# Altium schematic sheet Microcontroller.SchDoc(U_Microcontroller)
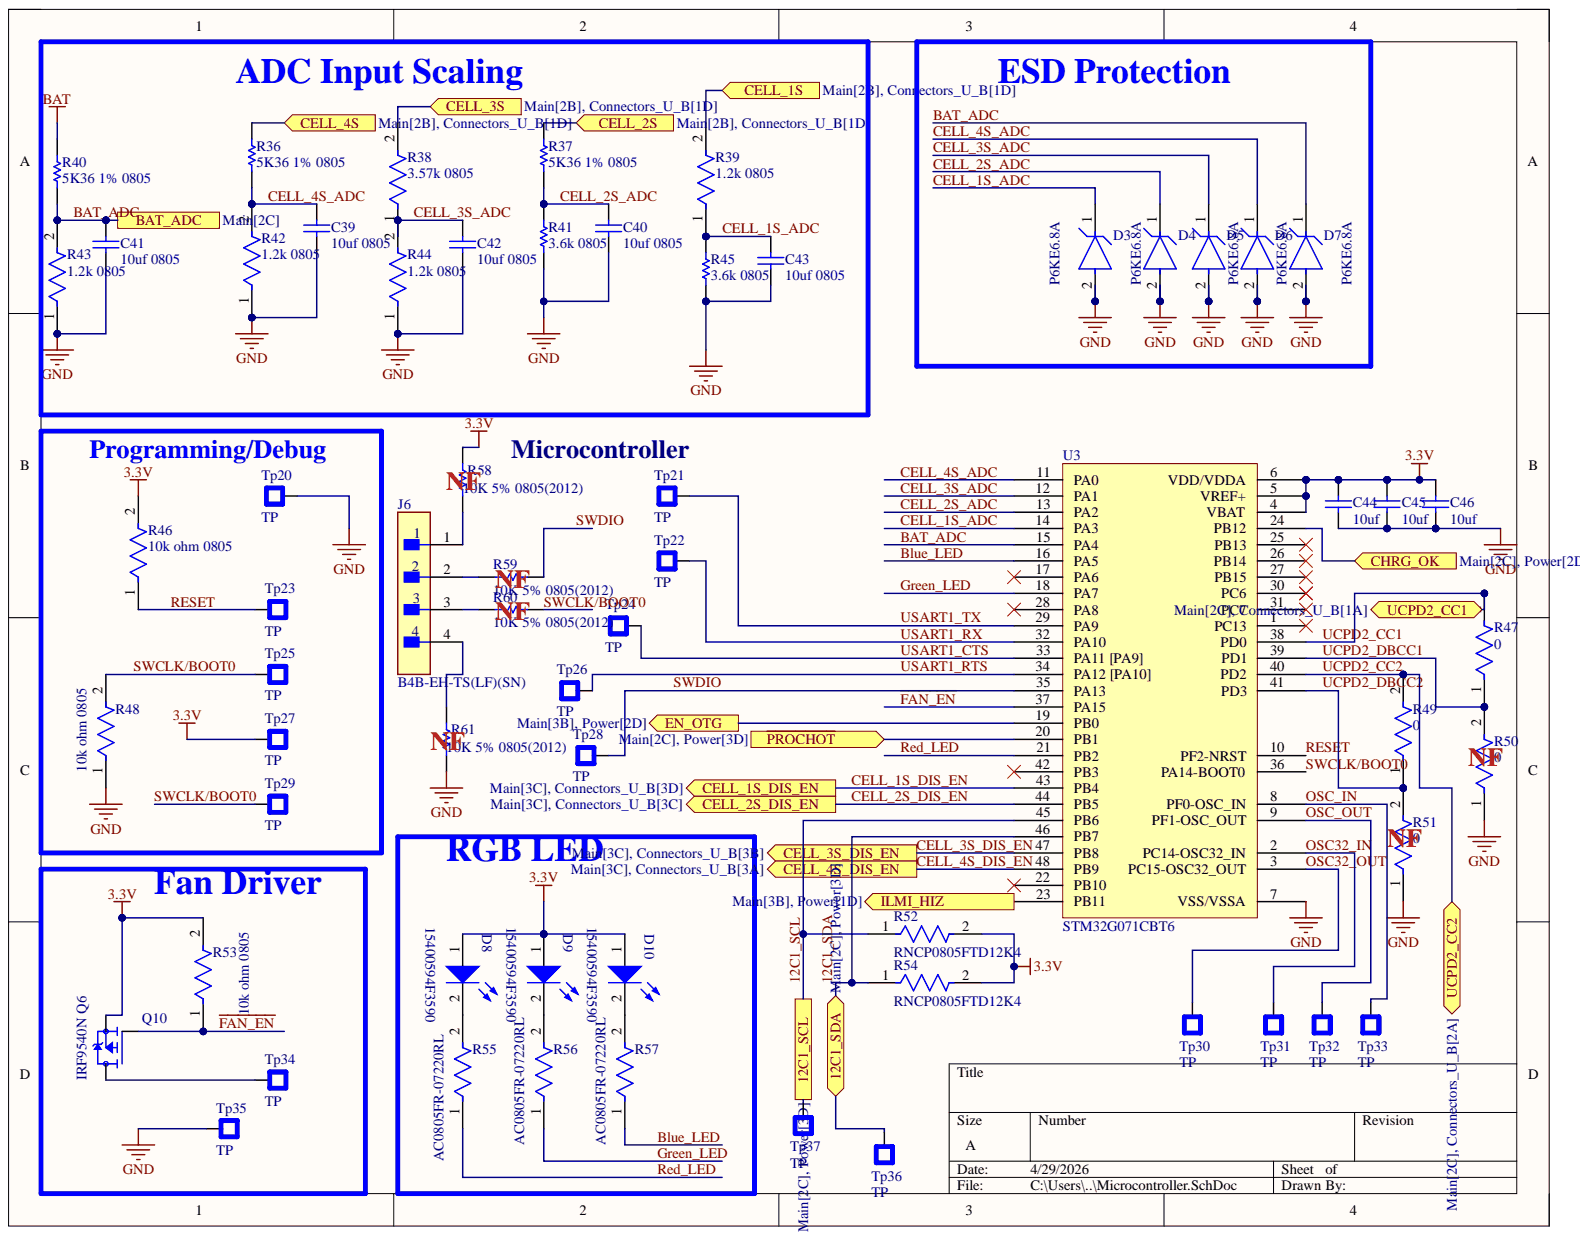
[diagram p0: Microcontroller.SchDoc]

## Parts
C39  10uf 0805  [CAPC2013X145X50NL20T20]
C40  10uf 0805  [CAPC2013X145X50NL20T20]
C41  10uf 0805  [CAPC2013X145X50NL20T20]
C42  10uf 0805  [CAPC2013X145X50NL20T20]
C43  10uf 0805  [CAPC2013X145X50NL20T20]
C44  10uf  [CAPC2013X145X50LL20T25]
C45  10uf  [CAPC2013X145X50LL20T25]
C46  10uf  [CAPC2013X145X50LL20T25]
D3  P6KE6.8A  [FP-P6KE-MFG]
D4  P6KE6.8A  [FP-P6KE-MFG]
D5  P6KE6.8A  [FP-P6KE-MFG]
D6  P6KE6.8A  [FP-P6KE-MFG]
D7  P6KE6.8A  [FP-P6KE-MFG]
D8  15400594F3590  [WL-TIRC_5mm]
D9  15400594F3590  [WL-TIRC_5mm]
D10  15400594F3590  [WL-TIRC_5mm]
J6  B4B-EH-TS(LF)(SN)  [FP-B4B-EH-TS_LF_SN-MFG]
Q10  IRF9540N Q6  MP: IRF9540N  [TO254P1041X444X1930-3]
R36  5K36 1% 0805  [RESC0805(2012)_M]
R37  5K36 1% 0805  [RESC0805(2012)_M]
R38  3.57k 0805  [FP-RT0805-MFG]
R39  1.2k 0805  Case/Package: 0805  [FP-RMCF0805-IPC_A]
R40  5K36 1% 0805  [RESC0805(2012)_M]
R41  3.6k 0805  Case-EIA: 0805  [RESC0805(2012)_L]
R42  1.2k 0805  [FP-RMCF0805-IPC_A]
R43  1.2k 0805  [FP-RMCF0805-IPC_A]
R44  1.2k 0805  Case/Package: 0805  [FP-RMCF0805-IPC_A]
R45  3.6k 0805  [RESC0805(2012)_L]
R46  10k ohm 0805  [FP-MCU0805-IPC_C]
R47  0  [FP-HCJ0805-MFG]
R48  10k ohm 0805  Case Code (Imperial): 0805  [FP-MCU0805-IPC_C]
R49  0  [FP-HCJ0805-MFG]
R50  0  [FP-HCJ0805-MFG]
R51  0  [FP-HCJ0805-MFG]
R52  RNCP0805FTD12K4  [FP-RNCP0805-IPC_C]
R53  10k ohm 0805  [FP-MCU0805-IPC_C]
R54  RNCP0805FTD12K4  [FP-RNCP0805-IPC_C]
R55  AC0805FR-07220RL  [FP-AC0805-MFG]
R56  AC0805FR-07220RL  [FP-AC0805-MFG]
R57  AC0805FR-07220RL  [FP-AC0805-MFG]
R58  10K 5% 0805(2012)  [RESC0805(2012)_L]
R59  10K 5% 0805(2012)  [RESC0805(2012)_L]
R60  10K 5% 0805(2012)  [RESC0805(2012)_L]
R61  10K 5% 0805(2012)  [RESC0805(2012)_L]
Tp20  TP  [Tp]
Tp21  TP  [Tp]
Tp22  TP  [Tp]
Tp23  TP  [Tp]
Tp24  TP  [Tp]
Tp25  TP  [Tp]
Tp26  TP  [Tp]
Tp27  TP  [Tp]
Tp28  TP  [Tp]
Tp29  TP  [Tp]
Tp30  TP  [Tp]
Tp31  TP  [Tp]
Tp32  TP  [Tp]
Tp33  TP  [Tp]
Tp34  TP  [Tp]
Tp35  TP  [Tp]
Tp36  TP  [Tp]
Tp37  TP  [Tp]
U3  STM32G071CBT6  [QFP50P900X900X160-48N]

## Ports
12C1_SCL, 12C1_SDA, BAT_ADC, CELL_1S, CELL_1S_DIS_EN, CELL_2S, CELL_2S_DIS_EN, CELL_3S, CELL_3S_DIS_EN, CELL_4S, CELL_4S_DIS_EN, CHRG_OK, EN_OTG, ILMI_HIZ, UCPD2_CC1, UCPD2_CC2, ~{PROCHOT}

## Nets
3.3V: C44.2 C45.2 C46.2 D8.1 D9.1 D10.1 Q10.3 R46.2 R52.2 R53.2 R54.2 R58.2 Tp27.1 U3.4(VBAT) U3.5(VREF+) U3.6(VDD/VDDA)
BAT: R40.2
GND: C39.1 C40.1 C41.1 C42.1 C43.1 C44.1 C45.1 C46.1 D3.2 D4.2 D5.2 D6.2 D7.2 R41.1 R42.1 R43.1 R44.1 R45.1 R48.1 R50.1 R51.1 R61.1 Tp20.1 Tp35.1 U3.7(VSS/VSSA)
12C1_SCL: R52.1 Tp37.1 U3.45(PB6) ; port 12C1_SCL
12C1_SDA: R54.1 Tp36.1 U3.46(PB7) ; port 12C1_SDA
~{PROCHOT}: U3.20(PB1) ; port ~{PROCHOT}
BAT_ADC: C41.2 D7.1 R40.1 R43.2 U3.15(PA4) ; port BAT_ADC
Blue_LED: R57.1 U3.16(PA5)
CELL_1S: R39.2 ; port CELL_1S
CELL_1S_ADC: C43.2 D3.1 R39.1 R45.2 U3.14(PA3)
CELL_1S_DIS_EN: U3.43(PB4) ; port CELL_1S_DIS_EN
CELL_2S: R37.2 ; port CELL_2S
CELL_2S_ADC: C40.2 D4.1 R37.1 R41.2 U3.13(PA2)
CELL_2S_DIS_EN: U3.44(PB5) ; port CELL_2S_DIS_EN
CELL_3S: R38.2 ; port CELL_3S
CELL_3S_ADC: C42.2 D5.1 R38.1 R44.2 U3.12(PA1)
CELL_3S_DIS_EN: U3.47(PB8) ; port CELL_3S_DIS_EN
CELL_4S: R36.2 ; port CELL_4S
CELL_4S_ADC: C39.2 D6.1 R36.1 R42.2 U3.11(PA0)
CELL_4S_DIS_EN: U3.48(PB9) ; port CELL_4S_DIS_EN
CHRG_OK: U3.24(PB12) ; port CHRG_OK
EN_OTG: U3.19(PB0) ; port EN_OTG
~{FAN_EN}: Q10.1 R53.1 U3.37(PA15)
Green_LED: R56.1 U3.18(PA7)
ILMI_HIZ: U3.23(PB11) ; port ILMI_HIZ
OSC32_IN: Tp31.1 U3.2(PC14-OSC32_IN)
OSC32_OUT: Tp30.1 U3.3(PC15-OSC32_OUT)
OSC_IN: Tp33.1 U3.8(PF0-OSC_IN)
OSC_OUT: Tp32.1 U3.9(PF1-OSC_OUT)
Red_LED: R55.1 U3.21(PB2)
RESET: R46.1 Tp23.1 U3.10(PF2-NRST)
SWCLK/BOOT0: R48.2 R60.2 Tp25.1 Tp29.1 U3.36(PA14-BOOT0)
SWDIO: R59.2 Tp28.1 U3.35(PA13)
UCPD2_CC1: R47.2 U3.38(PD0) ; port UCPD2_CC1
UCPD2_CC2: R49.2 U3.40(PD2) ; port UCPD2_CC2
UCPD2_DBCC1: R47.1 R50.2 U3.39(PD1)
UCPD2_DBCC2: R49.1 R51.2 U3.41(PD3)
USART1_CTS: Tp24.1 U3.33(PA11_[PA9])
USART1_RTS: Tp26.1 U3.34(PA12_[PA10])
USART1_RX: Tp22.1 U3.32(PA10)
USART1_TX: Tp21.1 U3.29(PA9)
NetD8_2: D8.2 R55.2
NetD9_2: D9.2 R56.2
NetD10_2: D10.2 R57.2
NetJ6_1: J6.1 R58.1
NetJ6_2: J6.2 R59.1
NetJ6_3: J6.3 R60.1
NetJ6_4: J6.4 R61.2
NetQ10_2: Q10.2 Tp34.1
NetU3_1: U3.1(PC13)
NetU3_17: U3.17(PA6)
NetU3_22: U3.22(PB10)
NetU3_25: U3.25(PB13)
NetU3_26: U3.26(PB14)
NetU3_27: U3.27(PB15)
NetU3_28: U3.28(PA8)
NetU3_30: U3.30(PC6)
NetU3_31: U3.31(PC7)
NetU3_42: U3.42(PB3)

## Library data of the parts
C39-C43 = CMP-2007-01676-1: Manufacturer: Vitramon; Part Number: VJ0805Y105KXQRW1BC; Component Kind: Standard; Library Name: Altium Content Vault
C44-C46 = CMP-2007-01044-1: Manufacturer: Kemet; Part Number: C0805C106K8RACTU; Component Kind: Standard; Library Name: Altium Content Vault
D3-D7 = CMP-08607-000072-1: TVS DIODE 5.8V 10.5V DO204AC; Manufacturer: Littelfuse Inc; Breakdown Voltage: 6.45V; Direction: Unidirectional; Max Junction Temp: +175°C; Number Of Channels: 1; Peak Pulse Current: 58.1A; Peak Pulse Power: 600W; Automotive: No; Category: Diode; Clamping Voltage: 10.5V; Component Kind: Standard; ComponentLink2Description: Datasheet; ComponentLink2URL: https://www.littelfuse.com/~/media/electronics/datasheets/tvs_diodes/littelfuse_tvs_diode_p6ke_datasheet.pdf.pdf; Device Class L1: Circuit Protection; Device Class L2: TVS Diodes; Device Class L3: unset; Digikey Description: TVS DIODE 5.8V 10.5V DO204AC; Height: 3.6mm; Ipc Land Pattern Name: DIOPA1328W105L760D360; Lead Free: Yes; Library Name: Altium Content Vault; Manufacturer Part Number: P6KE6.8A; Mouser Description: TVSS; Diode; For Data/Comm; Industrial Equip; 58.1A; Thru-Hole; Solder Term; Octopart: https://octopart.com/p6ke6.8a-littelfuse-20808; Package: P6KE; Reverse Standoff Voltage: 5.8V; RoHS: TRUE; Temperature: -55°C to +175°C; Temperature Range High: +175°C; Temperature Range Low: -55°C
D8-D10 = CMP-03913-110288041-2: Led, 5Mm, 940Nm, 30Mw/Sr, Th Rohs Compliant: Yes; Forward Current: 1A; Forward Voltage: 1.3V; Max Reverse Voltage (DC): 5V; Viewing Angle: 30°; Component Kind: Standard; Library Name: Altium Content Vault; Max Operating Temperature: 85°C; Min Operating Temperature: -40°C; Peak Wavelength: 940nm; RoHS: Compliant
J6 = CMP-17439-000699-1: CONN HEADER EH TOP 4POS 2.5MM; Number of Positions: 4; Case/Package: Radial; Component Kind: Standard; Lead Free: Lead Free; Library Name: Altium Content Vault; Pitch: 2.5mm; Termination: Crimp
Q10 = IRF9540N Q6: P-Channel 100 V 23A (Tc) 3.1W (Ta), 110W (Tc); MF: Infineon Technologies; Availability: In Stock; Check_prices: https://www.snapeda.com/parts/IRF9540N/Infineon+Technologies/view-part/?ref=eda; Component Kind: Standard; Package: TO-220 Infineon; Price: None; Purchase-URL: https://pricing.snapeda.com/search/part/IRF9540N/?ref=eda; SnapEDA_Link: https://www.snapeda.com/parts/IRF9540N/Infineon+Technologies/view-part/?ref=snap; Library Name: power_schi.SchLib
R36 R37 R40 = CMP-1013-00593-1: 5K36 0.125W 1% 0805 (2012 Metric)  SMD; Case-EIA: 0805; Case-Metric: 2012; Value: 5360.00 Ohm; Component Kind: Standard; Library Name: Altium Content Vault; Power: 0.125 W; Technology: SMT; Tolerance: 1 %
R38 = CMP-03412-012413-1: RES 357K OHM 1/8W .1% SMD 0805; Manufacturer: Yageo; Manufacturer Part Number: RT0805BRD07357KL; Power Rating: 0.125W; Resistance: 357KΩ; Temperature Coefficient: 25 ppm/°C; Tolerance: 0.0025; Value: 357KΩ; Voltage Rating: 150V; Automotive: No; Category: Res; Component Kind: Standard; ComponentLink2Description: Datasheet; ComponentLink2URL: http://www.yageo.com/documents/recent/PYu-RT_1-to-0.01_RoHS_L_9.pdf; Composition: Thin Film; Device Class L1: Passive Components; Device Class L2: Resistors; Device Class L3: Chip SMD Resistors; Digikey Description: RES 357K OHM 1/8W .1% SMD 0805; Footprint Url: http://www.yageo.com/exep/pages/download/literatures/PYu-R_Mount_10.pdf; Height: 0.6mm; Ipc Land Pattern Name: RESC200125X50; Lead Free: Yes; Library Name: Altium Content Vault; Mouser Description: Thin Film Resistors - SMD 1/8W 357K ohm .1% 25ppm; Octopart: https://octopart.com/rt0805brd07357kl-yageo-1483813; Package: RT0805; RoHS: TRUE; Series: RT; Temperature: -55°C to +155°C; Temperature Range High: +155°C; Temperature Range Low: -55°C
R39 R42-R44 = CMP-26527-005543-1: 1.2kΩ ±5% 0.125W 0805 Thick Film Chip Resistor AEC-Q200 compliant; Max Power Dissipation: 125mW; Resistance: 1.2kR; Temperature Coefficient: 200ppm/°C; Component Kind: Standard; Contact Plating: Tin; Features: Automotive AEC-Q200; Height: 0.026inch; Length: 0.079inch; Library Name: Altium Content Vault; Max Operating Temperature: 155°C; Min Operating Temperature: -55°C; Mount: Surface Mount; Number of Terminations: 2; Packaging: Tape and Reel; Radiation Hardening: No; Resistor Type: Thick Film; RoHS Compliant: Yes; Series: RMCF; Tolerance: 5%; Width: 0.049inch
  R42 R43: Case/Package: 0805
R41 = CMP-1013-00087-1: 3K6 0.125W 5% 0805 (2012 Metric)  SMD; Case-Metric: 2012; Value: 3600.00 Ohm; Component Kind: Standard; Library Name: Altium Content Vault; Power: 0.125 W; Technology: SMT; Tolerance: 5 %
R45 = CMP-1013-00087-1: 3K6 0.125W 5% 0805 (2012 Metric)  SMD; Case-EIA: 0805; Case-Metric: 2012; Value: 3600.00 Ohm; Component Kind: Standard; Library Name: Altium Content Vault; Power: 0.125 W; Technology: SMT; Tolerance: 5 %
R46 R48 R53 = CMP-02407-000241-1: RES 1.05K OHM 0.5% 1/5W 0805; Power Rating: 200mW; Resistance: 10kR; Tolerance: 0.1%; Voltage Rating: 150V; Case Code (Metric): 2012; Case/Package: 0805; Component Kind: Standard; Composition: Thin Film; Height: 0.52mm; Length: 2mm; Library Name: Altium Content Vault; Max Operating Temperature: 155°C; Min Operating Temperature: -55°C; Packaging: Tape and Reel; Weight: 0.000162oz; Width: 1.25mm
  R46 R53: Case Code (Imperial): 0805
R47 R49-R51 = CMP-26527-000104-1: RES 0 OHM JUMPER 1/2W 0805; Resistance: 0mR; Case Code (Imperial): 0805; Case Code (Metric): 2012; Case/Package: 0805; Component Kind: Standard; Height: 0.026inch; Lead Free: Lead Free; Length: 0.079inch; Library Name: Altium Content Vault; Max Operating Temperature: 155°C; Min Operating Temperature: -55°C; Mount: Surface Mount; Number of Terminations: 2; Package Quantity: 1; Packaging: Tape and Reel; Radiation Hardening: No; RoHS Compliant: Yes; Termination: Wraparound; Width: 0.049inch
R52 R54 = CMP-26527-001038-1: 12.4kΩ ±1% 0.25W 0805 High Power Anti-Sulfur Thin Film Chip Resistor; Max Power Dissipation: 250mW; Resistance: 12.4kR; Temperature Coefficient: 100ppm/°C; Case Code (Imperial): 0805; Case Code (Metric): 2012; Case/Package: 0805; Component Kind: Standard; Features: Moisture Resistant; Height: 0.024inch; Length: 0.079inch; Library Name: Altium Content Vault; Max Operating Temperature: 155°C; Min Operating Temperature: -55°C; Mount: Surface Mount; Number of Terminations: 2; Packaging: Tape and Reel; Resistor Type: Thin Film; RoHS Compliant: Yes; Series: RNCP; Tolerance: 1%; Width: 0.049inch
R55-R57 = CMP-2001-04488-2: RES SMD 220 OHM 1% 1/8W 0805; Manufacturer: Yageo; Automotive Grade: Grade 0; Resistance: 220Ω; Tolerance: 0.01; Value: 220Ω; Voltage Rating: 150V; Automotive: Yes; Category: Res; Component Kind: Standard; ComponentLink2Description: Datasheet; ComponentLink2URL: http://www.yageo.com/documents/recent/PYu-AC_51_RoHS_L_6.pdf; Composition: Thick Film; Device Class L1: Passive Components; Device Class L2: Resistors; Device Class L3: Chip SMD Resistors; Digikey Description: RES SMD 220 OHM 1% 1/8W 0805; Footprint Url: http://www.yageo.com/exep/pages/download/literatures/PYu-R_Mount_10.pdf; Height: 0.6mm; Ipc Land Pattern Name: RESC200125X50; Lead Free: Yes; Library Name: Altium Content Vault; Manufacturer Part Number: AC0805FR-07220RL; Mouser Description: Thick Film Resistors - SMD 1/8W 220ohm 1% AEC-Q200; Octopart: https://octopart.com/ac0805fr-07220rl-yageo-26041145; Package: AC0805; Power Rating: 1/8W; RoHS: TRUE; Series: AC; Temperature: -55°C to +155°C; Temperature Range High: +155°C; Temperature Range Low: -55°C
R58-R61 = CMP-1013-00098-1: 10K 0.125W 5% 0805 (2012 Metric)  SMD; Case-EIA: 0805; Case-Metric: 2012; Value: 10000.00 Ohm; Component Kind: Standard; Library Name: Altium Content Vault; Power: 0.125 W; Technology: SMT; Tolerance: 5 %
U3 = STM32G071CBT6: MCU 32-bit ARM Cortex M0+ RISC 128KB Flash 1.8V/2.5V/3.3V 48-Pin LQFP Tray; Manufacturer: STMicroelectronics; ADC Channels (Resolution): 14-Ch 12-Bit; Clock Frequency: 48MHz; Core Architecture: ARM Cortex-M0+; DAC Channels (Resolution): 2-Ch 12-Bit; Data Bus Width: 32b; EEPROM Memory Size: 0B; Frequency: 64MHz; Interface: I2S; Max Junction Temperature (Tj): 105°C; Max Supply Current: 7mA; Max Supply Voltage: 3.6V; Min Supply Voltage: 1.7V; Number of A/D Converters: 1; Number of ADC Channels: 17; Number of Channels: 14; Number of D/A Converters: 2; Number of I/Os: 5; Number of Interrupts: 44; Number of Timers/Counters: 12; Number of UART Channels: 0; Number of USART Channels: 4; Operating Supply Voltage: 1.8V; Peripherals: POR, PWM, WDT; RAM Size: 36kB; Ambient Temperature Range High: 85°C; Case/Package: LFQFP; Category: Microcontrollers; China RoHS: Compliant; Component Kind: Standard; ComponentLink1Description: https://datasheet.octopart.com:443/STM32G071CBT6-STMicroelectronics-datasheet-159144893.pdf?src-supplier=IHS; ComponentLink1URL: https://datasheet.octopart.com:443/STM32G071CBT6-STMicroelectronics-datasheet-159144893.pdf?src-supplier=IHS; ComponentLink2Description: https://datasheet.octopart.com:443/STM32G071CBT6-STMicroelectronics-datasheet-132344621.pdf?src-supplier=ODG+(Origin+Data+Global); ComponentLink2URL: https://datasheet.octopart.com:443/STM32G071CBT6-STMicroelectronics-datasheet-132344621.pdf?src-supplier=ODG+(Origin+Data+Global); ComponentLink3Description: https://datasheet.octopart.com:443/STM32G071CBT6-STMicroelectronics-datasheet-130125765.pdf?src-supplier=element14+APAC; ComponentLink3URL: https://datasheet.octopart.com:443/STM32G071CBT6-STMicroelectronics-datasheet-130125765.pdf?src-supplier=element14+APAC; Country of Origin: Mainland China; Export Control Classification Number (ECCN) Code: 3A991.A.2; Flash Memory Size: 128kB; Harmonized Tariff Schedule (HTS) Code: 8542.31.00.25; Height: 1.6mm; Height - Seated (Max): 1.6mm; Introduction Date: 2019-03-07; Length: 7mm; Lifecycle Status: Production; Manufacturer Part Number: STM32G071CBT6; Max Operating Temperature: 85°C; Memory Format: FLASH; Memory Size: 128kB; Min Operating Temperature: -40°C; Number of I2C Channels: 2; Number of SPI Channels: 2; Number of Terminals: 48; Number of USB Channels: 2; Pricing: 1=3.97, 10=3.29, 25=3.08, 50=2.97, 100=2.86, 250=2.76, 500=2.69 (USD); REACH SVHC: Yes; RoHS: Compliant; Schedule B: 8542310000, 8542310000|8542310000, 8542310000|8542310000|8542310000|8542310000|8542310000; Stock: 1757; Supplier: Avnet; Supplier Part Number: 84AC2899; Terminal Pitch: 500µm; Width: 7mm; Library Name: power_schi.SchLib
Tp20-Tp37 = TP: Component Kind: Standard; Library Name: power_schi.SchLib
